# Altium schematic sheet Digital Control.SchDoc("Digital Control")
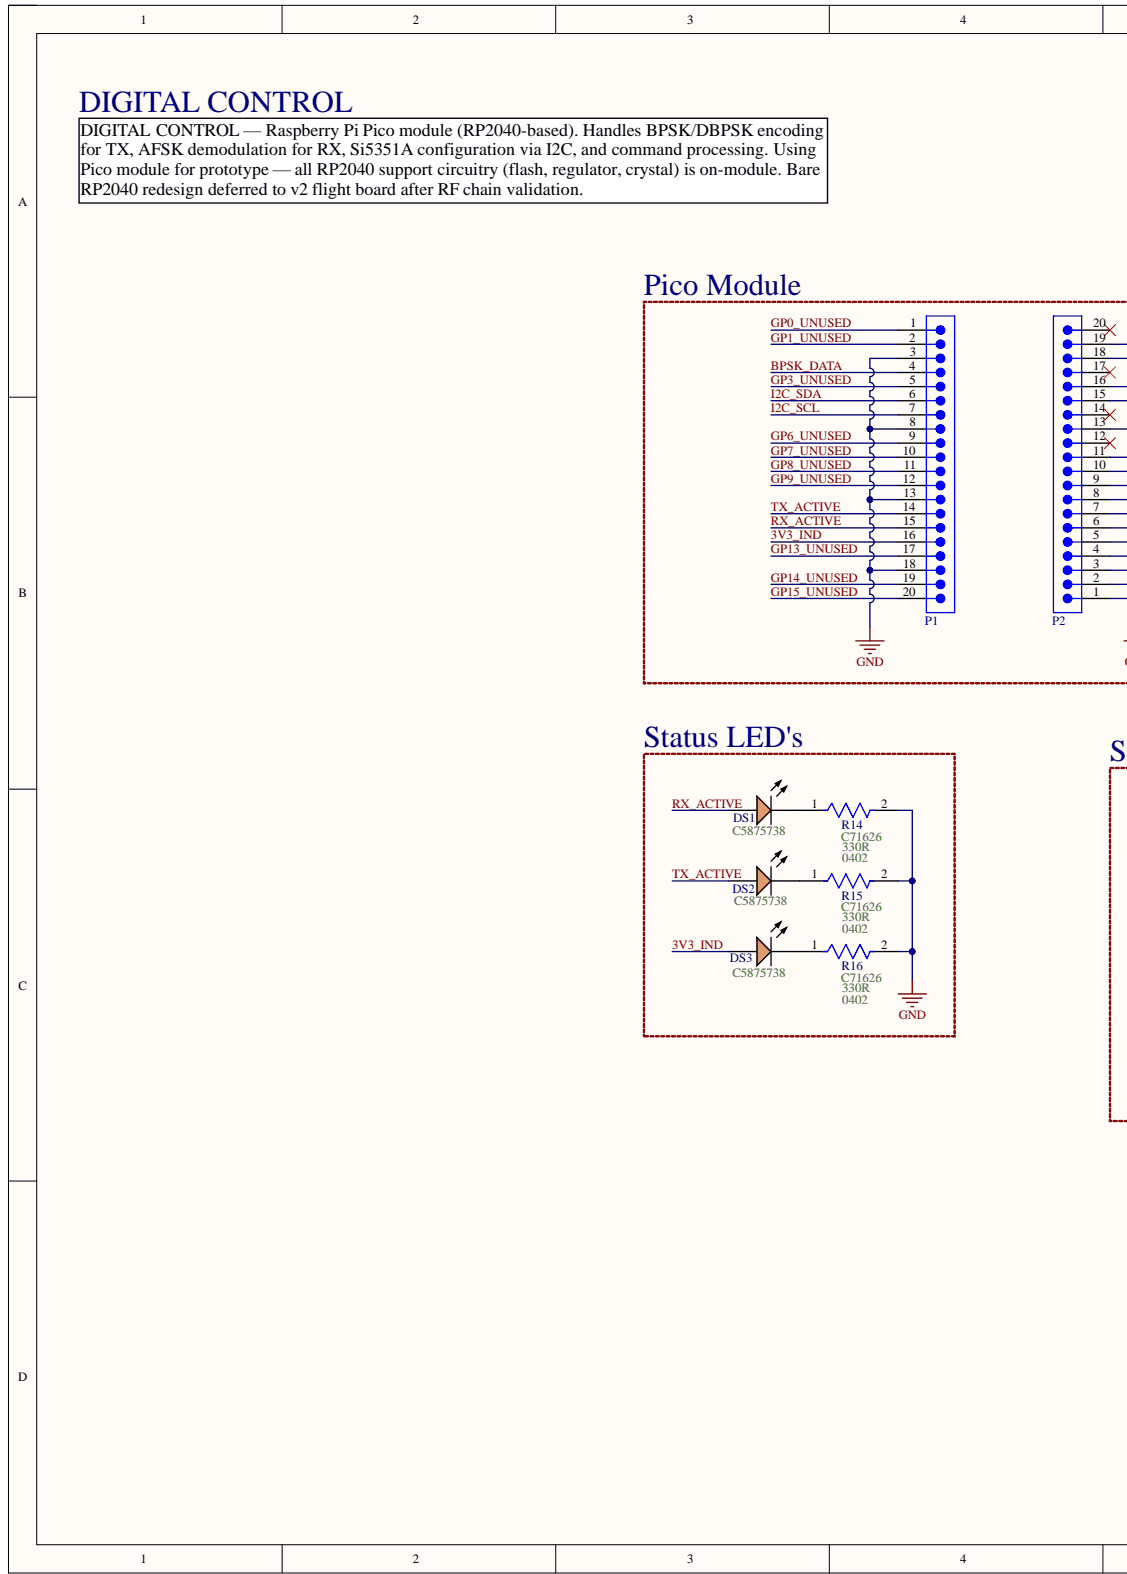
[diagram p0: Digital Control.SchDoc, left]
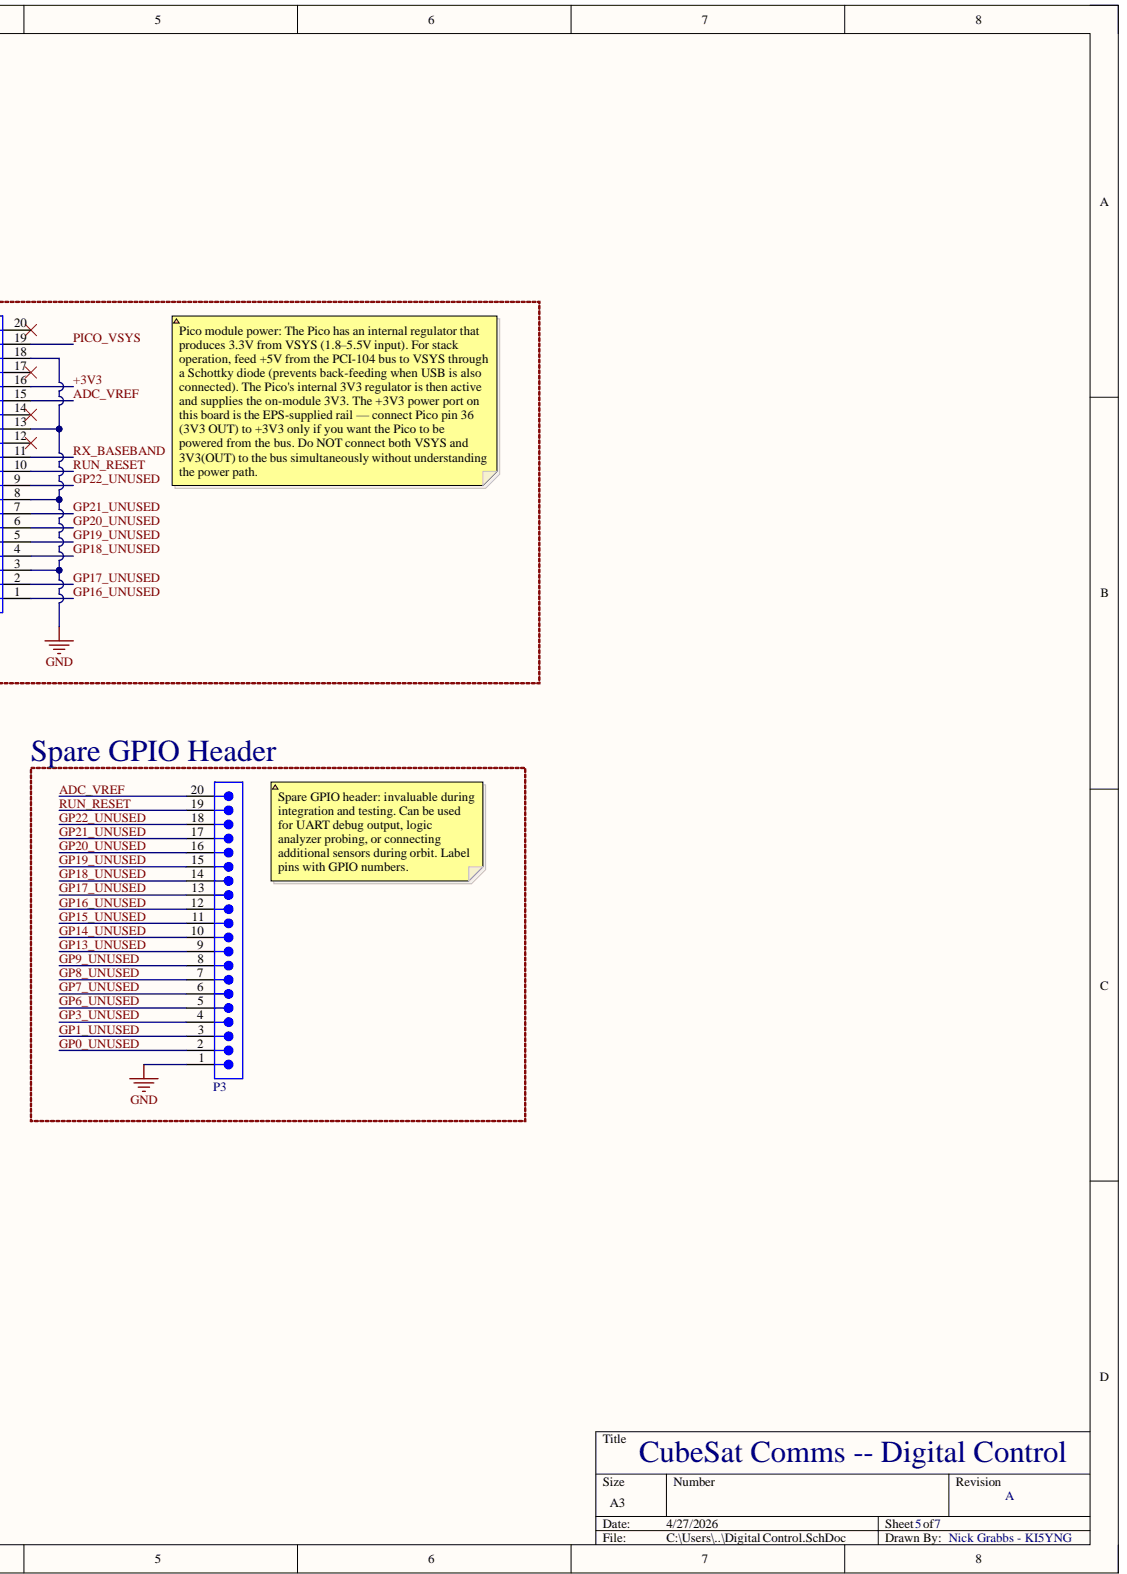
[diagram p1: Digital Control.SchDoc, right]

## Parts
DS1  LCSC Part #: C5875738  [KING-LED0603-25-ORANGE_V]  (hidden comment: APG1608SEKC/T)
DS2  LCSC Part #: C5875738  [KING-LED0603-25-ORANGE_V]  (hidden comment: APG1608SEKC/T)
DS3  LCSC Part #: C5875738  [KING-LED0603-25-ORANGE_V]  (hidden comment: APG1608SEKC/T)
P1  MMT-120-01-L-SH  [SMTC-MMT-120-01-X-SH]  (value not drawn)
P2  MMT-120-01-L-SH  [SMTC-MMT-120-01-X-SH]  (value not drawn)
P3  MMT-120-01-L-SH  [SMTC-MMT-120-01-X-SH]  (value not drawn)
R14  LCSC Part #: C71626  Resistance: 330R  [FP-CRCW0402-e3-MFG]  (hidden comment: CRCW0402330RFKED)
R15  Case Code (Imperial): 0402  LCSC Part #: C71626  Resistance: 330R  [FP-CRCW0402-e3-MFG]  (hidden comment: CRCW0402330RFKED)
R16  Case Code (Imperial): 0402  LCSC Part #: C71626  Resistance: 330R  [FP-CRCW0402-e3-MFG]  (hidden comment: CRCW0402330RFKED)

## Nets
GND: P1.3 P1.8 P1.13 P1.18 P2.3 P2.8 P2.13 P2.18 P3.1 R14.2 R15.2 R16.2
3V3_IND: DS3.1 P1.16 P2.16  [also labelled +3V3]
ADC_VREF: P2.15 P3.20
BPSK_DATA: P1.4
GP0_UNUSED: P1.1 P3.2
GP1_UNUSED: P1.2 P3.3
GP3_UNUSED: P1.5 P3.4
GP6_UNUSED: P1.9 P3.5
GP7_UNUSED: P1.10 P3.6
GP8_UNUSED: P1.11 P3.7
GP9_UNUSED: P1.12 P3.8
GP13_UNUSED: P1.17 P3.9
GP14_UNUSED: P1.19 P3.10
GP15_UNUSED: P1.20 P3.11
GP16_UNUSED: P2.1 P3.12
GP17_UNUSED: P2.2 P3.13
GP18_UNUSED: P2.4 P3.14
GP19_UNUSED: P2.5 P3.15
GP20_UNUSED: P2.6 P3.16
GP21_UNUSED: P2.7 P3.17
GP22_UNUSED: P2.9 P3.18
I2C_SCL: P1.7
I2C_SDA: P1.6
PICO_VSYS: P2.19
RUN_RESET: P2.10 P3.19
RX_ACTIVE: DS1.1 P1.15
RX_BASEBAND: P2.11
TX_ACTIVE: DS2.1 P1.14
NetDS1_2: DS1.2 R14.1
NetDS2_2: DS2.2 R15.1
NetDS3_2: DS3.2 R16.1

Not connected: P2 12 14 17 20

## Library data of the parts
DS1-DS3 = CMP-0239-00002-1: LED, SMT, 0603(1608), 0.25mm Tthickness, Super Bright Orange; Forward Current: 30 mA; Packing: Reel; Power Dissipation: 75 mW; Reverse Voltage: 5 V; Component Kind: Standard; ComponentLink1Description: Manufacturer URL; ComponentLink1URL: http://www.kingbrightusa.com/; ComponentLink2Description: Datasheet; ComponentLink2URL: http://www.kingbrightusa.com/images/catalog/SPEC/APG1608SEKC-T.pdf; DatasheetVersion: Rev. 4A, 12/2011; Library Name: Altium Content Vault; Mounting Technology: Surface Mount; PackageDescription: 2-Pin SMT LED, 1.6 x 0.8 mm Body, 0.25mm Thickness; PackageReference: LED0603-25-ORANGE; PackageVersion: Rev. 4A, 12/2011; PartNumber: APG1608SEKC/T; Storage Temperature: -40 to 85 degC
P1-P3 = CMP-1029-00077-1: Horizontal Surface Mount Header, -55 to 125 degC, 2 mm Pitch, 20-Pin, Male, RoHS; Manufacturer: Samtec; Pitch: 2 mm; Component Kind: Standard; ComponentLink1Description: Manufacturer URL; ComponentLink1URL: http://www.samtec.com; ComponentLink2Description: Datasheet; ComponentLink2URL: http://d2a072wq521u33.cloudfront.net/catalog_english//MMT_SM.PDF; ComponentLink3Description: Package Specification; ComponentLink3URL: http://www.samtec.com/documents/webfiles/cpdf/MMT-1XX-XX-XX-XX-XX-XXX-X-XX-MKT.pdf; DatasheetVersion: F-214; Library Name: Altium Content Vault; Mounting Technology: Surface Mount; Orientation: Horizontal; PackageDescription: 2mm Horizontal Terminal Surface Mount Strip ASSEM; PackageReference: MMT-120-01-X-SH; PackageVersion: CY, 12/2000; PartNumber: MMT-120-01-L-SH; RoHS: True; Temperature Range: -55 to 125 degC
R14-R16 = CMP-2002-08523-2: RES Thick Film, 330Ω, 1%, 0.063W, 100ppm/°C, 0402; Max Power Dissipation: 63mW; Voltage Rating: 50V; Case Code (Metric): 1005; Case/Package: 0402; Component Kind: Standard; Composition: Thick Film; Contact Plating: Tin; Depth: 0.5mm; Features: Automotive AEC-Q200; Height: 0.35mm; Lead Free: Lead Free; Length: 1mm; Library Name: Altium Content Vault; Max Operating Temperature: 155°C; Military Standard: Not; Min Operating Temperature: -55°C; Number of Terminations: 2; Package Quantity: 10; Packaging: Tape and Reel; Power Rating: 63mW; Radiation Hardening: No; Temperature Coefficient: 100ppm/°C; Termination: SMD/SMT; Tolerance: 1%; Voltage Rating (DC): 50V; Weight: 2.3E-05oz; Width: 0.5mm
  R14: Case Code (Imperial): 0402
